# Altium schematic sheet TargetAndSample.SchDoc(TargetAndSample)
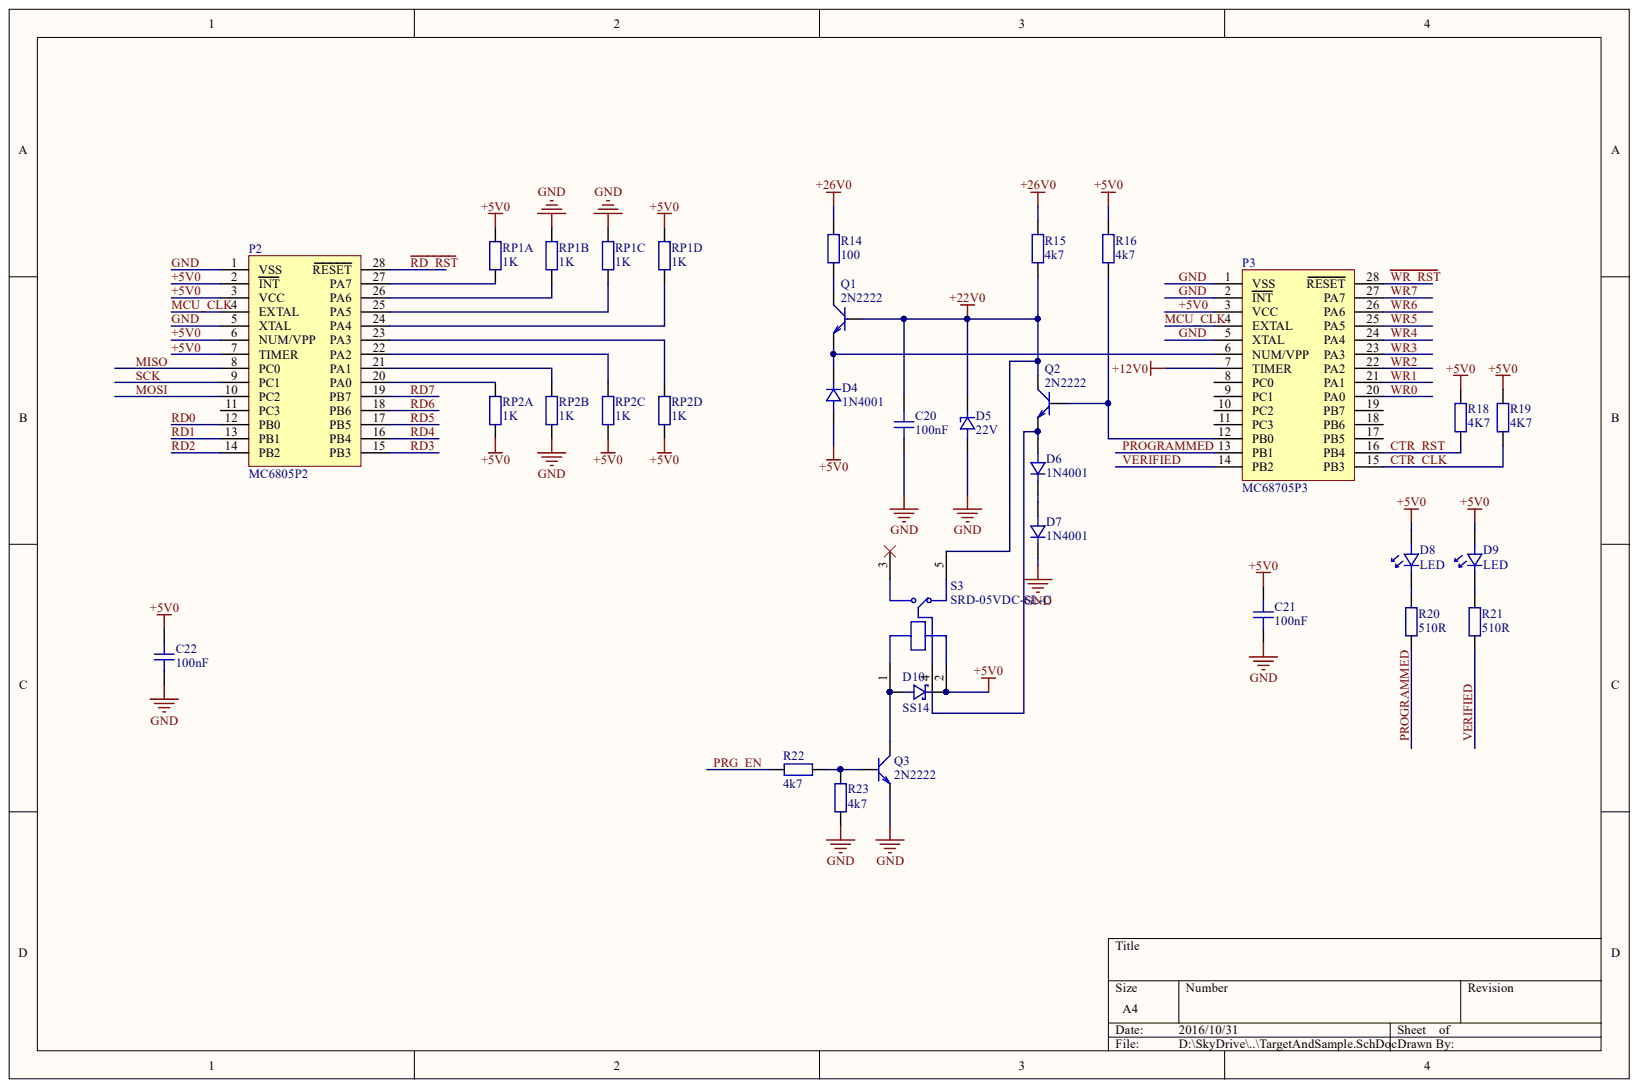
[diagram p0: TargetAndSample.SchDoc]

## Parts
C20  100nF  [CAPC2012N]
C21  100nF  [CAPC2012N]
C22  100nF  [CAPC2012N]
D4  1N4001  [DIOM5326X230N]
D5  22V  [SOD3716X135N]
D6  1N4001  [DIOM5326X230N]
D7  1N4001  [DIOM5326X230N]
D8  LED  [LEDC2012N]
D9  LED  [LEDC2012N]
D10  SS14  [SOD3716X135N]
P2  MC6805P2  [28P_DIP_SOCKET]
P3  MC68705P3  [28P_DIP_SOCKET]
Q1  2N2222  [SOT23-3N]
Q2  2N2222  [SOT23-3N]
Q3  2N2222  [SOT23-3N]
R14  100  [RESC3216N]
R15  4k7  [RESC3216N]
R16  4k7  [RESC2012N]
R18  4K7  [RESC2012N]
R19  4K7  [RESC2012N]
R20  510R  [RESC2012N]
R21  510R  [RESC2012N]
R22  4k7  [RESC2012N]
R23  4k7  [RESC2012N]
RP1  1K  [RESCAXS80P150X320X45-8N]  (parts A,B,C,D)
RP2  1K  [RESCAXS80P150X320X45-8N]  (parts A,B,C,D)
S3  SRD-05VDC-SL-C  [SRD-xVDC-SL-C]

## Nets
+12V0: P3.7(TIMER)
+22V0: C20.2 D5.1 Q1.1 Q2.3 R15.1 S3.5
+26V0: R14.2 R15.2
+5V0: C21.2 C22.2 D4.2 D8.2 D9.2 D10.1 P2.2(INT) P2.3(VCC) P2.6(NUM/VPP) P2.7(TIMER) P3.3(VCC) R16.2 R18.1 R19.1 RP1.5 RP1.8 RP2.1 RP2.3 RP2.4 S3.2
CTR_CLK: P3.15(PB3) R19.2
CTR_RST: P3.16(PB4) R18.2
GND: C20.1 C21.1 C22.1 D5.2 D7.1 P2.1(VSS) P2.5(XTAL) P3.1(VSS) P3.2(INT) P3.5(XTAL) Q3.2 R23.1 RP1.6 RP1.7 RP2.2
MCU_CLK: P2.4(EXTAL) P3.4(EXTAL)
MISO: P2.8(PC0)
MOSI: P2.10(PC2)
PRG_EN: R22.2
PROGRAMMED: P3.13(PB1) R20.1
~{RD_RST}: P2.28(RESET)
RD0: P2.12(PB0)
RD1: P2.13(PB1)
RD2: P2.14(PB2)
RD3: P2.15(PB3)
RD4: P2.16(PB4)
RD5: P2.17(PB5)
RD6: P2.18(PB6)
RD7: P2.19(PB7)
SCK: P2.9(PC1)
VERIFIED: P3.14(PB2) R21.1
~{WR_RST}: P3.28(RESET)
WR0: P3.20(PA0)
WR1: P3.21(PA1)
WR2: P3.22(PA2)
WR3: P3.23(PA3)
WR4: P3.24(PA4)
WR5: P3.25(PA5)
WR6: P3.26(PA6)
WR7: P3.27(PA7)
NetD4_1: D4.1 P3.6(NUM/VPP) Q1.2
NetD6_1: D6.1 D7.2
NetD6_2: D6.2 Q2.2 S3.4
NetD8_1: D8.1 R20.2
NetD9_1: D9.1 R21.2
NetD10_2: D10.2 Q3.3 S3.1
NetP2_20: P2.20(PA0) RP2.8
NetP2_21: P2.21(PA1) RP2.7
NetP2_22: P2.22(PA2) RP2.6
NetP2_23: P2.23(PA3) RP2.5
NetP2_24: P2.24(PA4) RP1.4
NetP2_25: P2.25(PA5) RP1.3
NetP2_26: P2.26(PA6) RP1.2
NetP2_27: P2.27(PA7) RP1.1
NetP3_12: P3.12(PB0) Q2.1 R16.1
NetQ1_3: Q1.3 R14.1
NetQ3_1: Q3.1 R22.1 R23.2

Not connected: P2 11(PC3); P3 8(PC0) 9(PC1) 10(PC2) 11(PC3) 17(PB5) 18(PB6) 19(PB7); S3 3

## Library data of the parts
C20-C22 = CAP_CHP: Surface Mount Unpolarized Capacitor; Component Kind: Standard; Library Name: Discrete.SchLib
D4 D6 D7 = DIO_SMD: Surface Mount General Purpose Diode; Component Kind: Standard; Library Name: Discrete.SchLib
D5 = ZEN_SMD: Surface Mount Zener Diode; Component Kind: Standard; Library Name: Discrete.SchLib
D8 D9 = LED_SMD: Surface Mount LED; Component Kind: Standard; Library Name: Discrete.SchLib
D10 = SKT_SMD: Surface Mount Diode; Component Kind: Standard; Library Name: Discrete.SchLib
P2 P3 = 28P_DIP_SOCKET: Component Kind: Standard; Library Name: Connector.SchLib
Q1-Q3 = TRAN_NPN: Surface Mount NPN Transistor; Component Kind: Standard; Library Name: Discrete.SchLib
R14-R16 R18-R23 = RES_CHP: Surface Mount Resistor; Component Kind: Standard; Library Name: Discrete.SchLib
RP1 RP2 = RES_ARR: 0603X4 Resistor Pack; Component Kind: Standard; Library Name: Discrete.SchLib
S3 = SRD-xVDC-SL-C: Single Pole Double Throw Relay; Component Kind: Standard; Library Name: Discrete.SchLib
